# Altium schematic sheet PowerSection.SchDoc
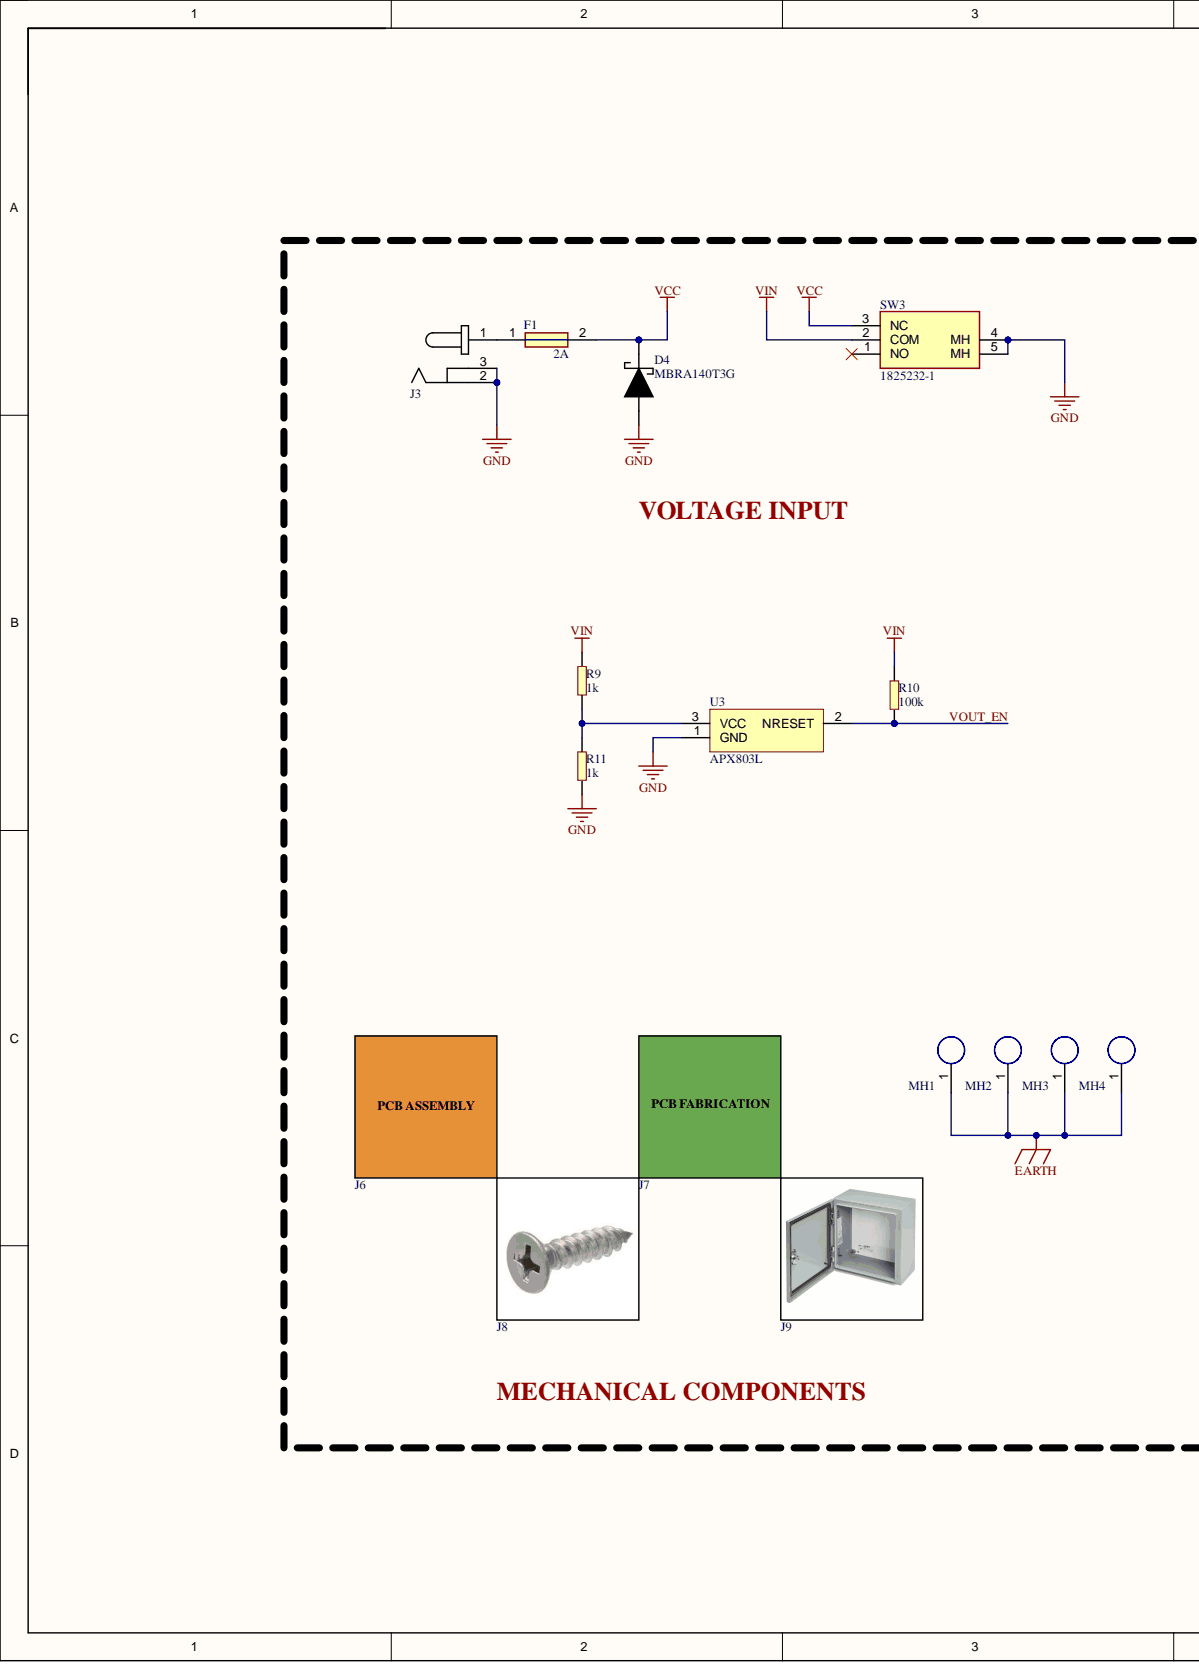
[diagram p0: PowerSection.SchDoc, left]
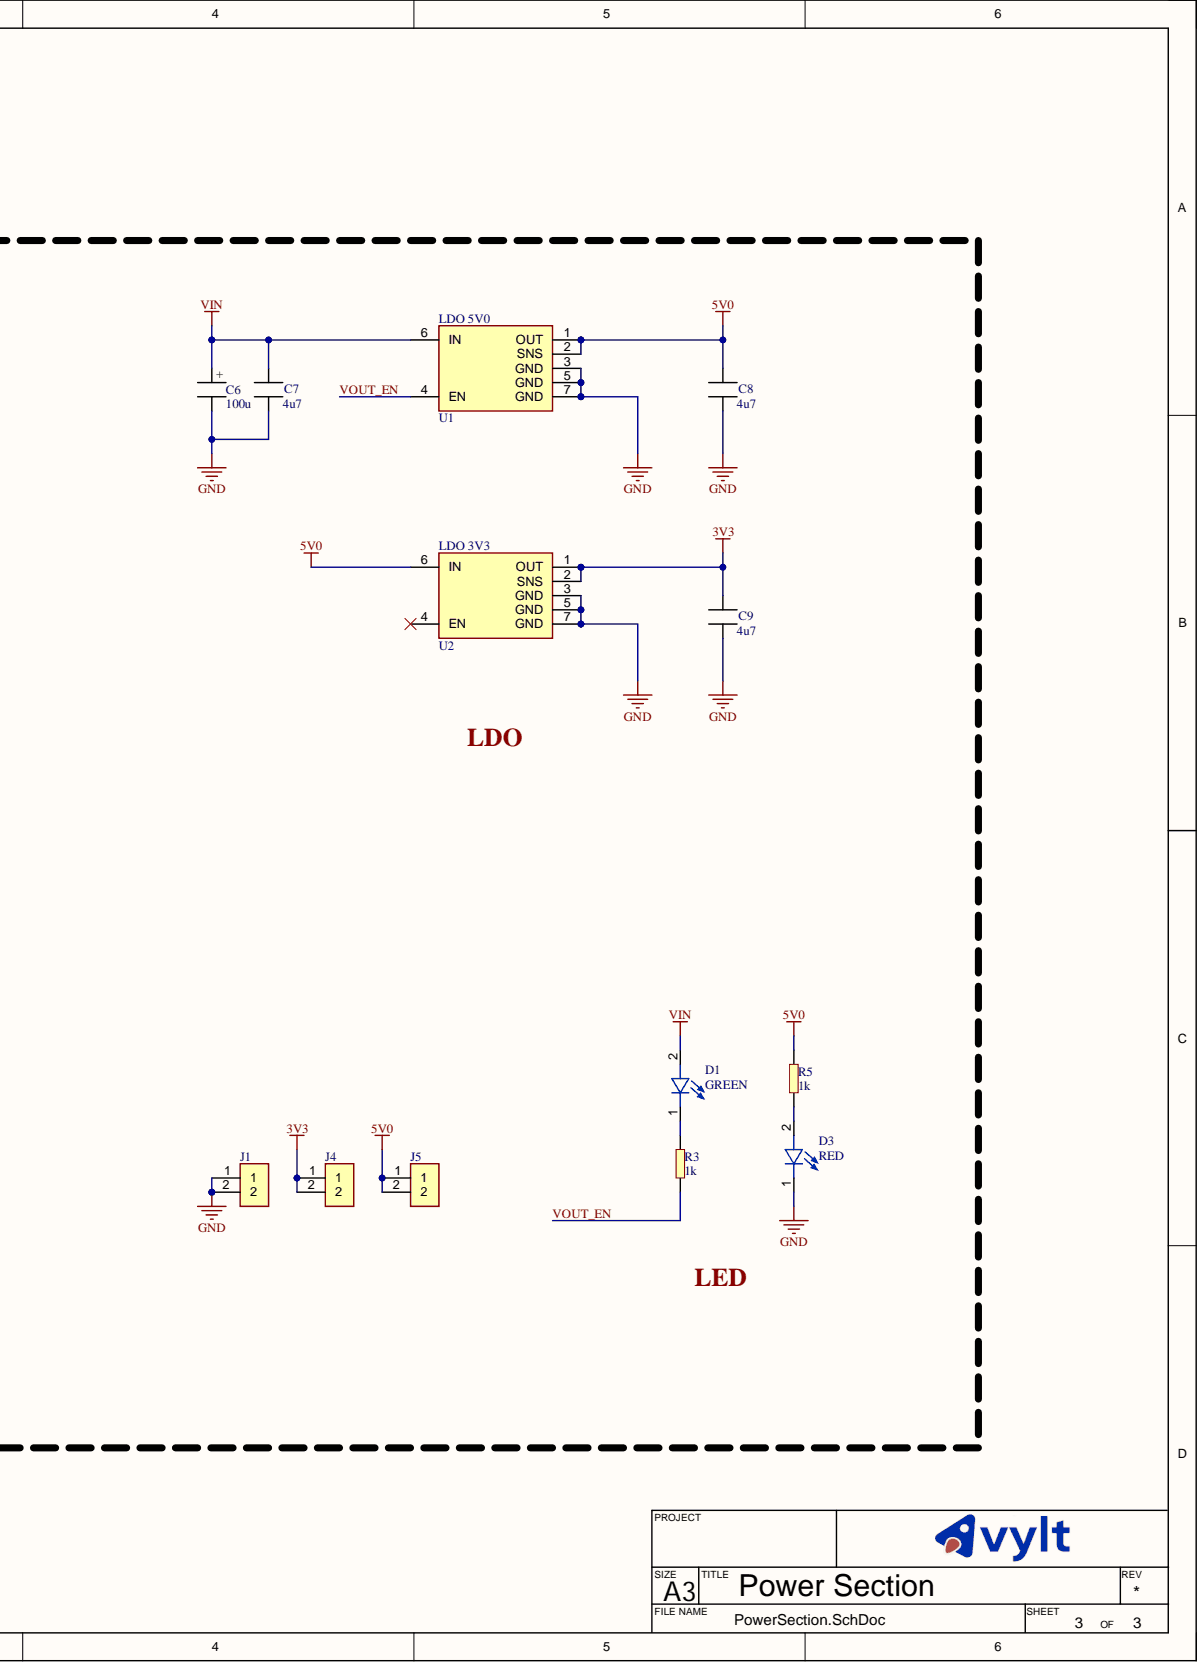
[diagram p1: PowerSection.SchDoc, right]

## Parts
C6  value 100u  [CAP_6.3_7.7]  (hidden comment: ERP_102-2)
C7  value 4u7  [CAPC1608X10N]  (hidden comment: ERP_102-1)
C8  value 4u7  [CAPC1608X10N]  (hidden comment: ERP_102-1)
C9  value 4u7  [CAPC1608X10N]  (hidden comment: ERP_102-1)
D1  value GREEN  [LED_2SMD]  (hidden comment: LED_2SMD)
D3  value RED  [LED_2SMD]  (hidden comment: LED_2SMD)
D4  value MBRA140T3G  Manufacturer Part Number 1: MBRA140T3G  [SS14_SMA]  (hidden comment: ERP_104-3)
F1  value 2A  [0679L]  (hidden comment: 0679L)
J1  ERP_148-2  [2PX1R]  (value not drawn)
J3  KLDX-0202-AC  [KLDX-0202-AC]  (value not drawn)
J4  ERP_148-2  [2PX1R]  (value not drawn)
J5  ERP_148-2  [2PX1R]  (value not drawn)
R3  value 1k  [RES_0603]  (hidden comment: ERP_101-1)
R5  value 1k  [RES_0603]  (hidden comment: ERP_101-1)
R9  value 1k  [RES_0603]  (hidden comment: ERP_101-1)
R10  value 100k  [RES_0603]  (hidden comment: ERP_101-1)
R11  value 1k  [RES_0603]  (hidden comment: ERP_101-1)
SW3  value 1825232-1  Manufacturer Part Number 1: 1825232-1  [3PIN_SPDT_TH_RA]  (hidden comment: ERP_110-4)
U1  value LDO 5V0  [TLV767XXDRV]  (hidden comment: TLV767XXDRV)
U2  value LDO 3V3  [TLV767XXDRV]  (hidden comment: TLV767XXDRV)
U3  APX803L  [APX803L]

## Nets
3V3: C9.1 J4.1 J4.2 U2.1(OUT) U2.2(SNS)
5V0: C8.1 J5.1 J5.2 R5.1 U1.1(OUT) U1.2(SNS) U2.6(IN)
GND: C6.2 C7.2 C8.2 C9.2 D3.1 D4.2 J1.1 J1.2 J3.2 J3.3 R11.1 SW3.4(MH) SW3.5(MH) U1.3(GND) U1.5(GND) U1.7(GND) U2.3(GND) U2.5(GND) U2.7(GND) U3.1
VCC: D4.1 F1.2 SW3.3(NC)
VIN: C6.1 C7.1 D1.2 R9.2 R10.2 SW3.2(COM) U1.6(IN)
VOUT_EN: R3.1 R10.1 U1.4(EN) U3.2
NetD1_1: D1.1 R3.2
NetD3_2: D3.2 R5.2
NetF1_1: F1.1 J3.1
NetR9_1: R9.1 R11.2 U3.3
EARTH:

Not connected: SW3 1(NO); U2 4(EN)

## Library data of the parts
C6 = CAP_6.3_7.7: Electrolytic Capacitor SMD 6.3x7.7; Manufacturer 1: Nichicon; Manufacturer Part Number 1: UWT1V101MCL1GS; Suuplier Part Number 1: 493-2203-1-ND; Component Kind: Standard; Library Name: ElectrolyticCapacitor.SchLib; Package: 6.3x7.7; Supplier 1: Digikey; Supplier Part Number 1: 493-2203-1-ND
C7-C9 = MLCC_0603: MLCC 0603; Manufacturer 1: Murata; Manufacturer Part Number 1: GRM188R6YA475KE15D; Component Kind: Standard; Library Name: Capacitor.SchLib; Package: 0603; Supplier 1: Digikey; Supplier Part Number 1: 490-7205-1-ND
D1 = LED_2SMD: LED GREEN CLEAR 1204 SMD R/A; Manufacturer 1: Würth Elektronik; Manufacturer Part Number 1: 155124VS73200; Component Kind: Standard; Library Name: LED.SchLib; Package: 1204; Supplier 1: Digikey
D3 = LED_2SMD: LED RED CLEAR 1204 SMD R/A; Manufacturer 1: Würth Elektronik; Manufacturer Part Number 1: 155124RS73200; Component Kind: Standard; Library Name: LED.SchLib; Package: 1204; Supplier 1: Digikey
D4 = SS14_SMA: Manufacturer 1: ONSEMi; Component Kind: Standard; Description: SS14_SMA; Library Name: Schottky.SchLib; Package: SMA; Supplier 1: Digikey
F1 = 0679L: FUSE BRD MNT 2SMD; Manufacturer 1: Bel Fuse Inc.; Manufacturer Part Number 1: 0679L2000-05; Component Kind: Standard; Library Name: BlowFuse.SchLib
J1 J4 J5 = 2PX1R: Component Kind: Standard; Description: 2PX1R; Library Name: Male_Header_P2.54.SchLib
J3 = KLDX-0202-AC: CONN PWR JACK 2X5.5MM KINKED PIN; Component Kind: Standard; Library Name: Connectors.SchLib
R3 = RES_0603: Manufacturer 1: YAGEO; Manufacturer Part Number 1: RC0603JR-07330RL; Component Kind: Standard; Description: RES_0603; Library Name: Resistor.SchLib; Package: 0603
R5 = RES_0603: Manufacturer 1: YAGEO; Manufacturer Part Number 1: RC0603FR-071KL; Component Kind: Standard; Description: RES_0603; Library Name: Resistor.SchLib; Package: 0603; Supplier 1: Digikey; Supplier Part Number 1: 311-1.00KHRCT-ND
R9-R11 = RES_0603: Component Kind: Standard; Description: RES_0603; Library Name: Resistor.SchLib; Package: 0603
SW3 = 3PIN_SPDT_TH_RA: Manufacturer 1: TE; Component Kind: Standard; Library Name: Switch.SchLib; Package: 6X6; Supplier 2: Digikey; Supplier Part Number 2: A107673-ND
U1 = TLV767XXDRV: IC REG LINEAR; Manufacturer 1: Texas Instruments; Manufacturer Part Number 1: TLV76750DRVR; Component Kind: Standard; Library Name: VoltageRegulator.SchLib
U2 = TLV767XXDRV: IC REG LINEAR; Manufacturer 1: Texas Instruments; Manufacturer Part Number 1: TLV76733DRVR; Component Kind: Standard; Library Name: VoltageRegulator.SchLib
U3 = APX803L: IC SUPERVISOR 1 CHANNEL SOT23; Manufacturer 1: Diodes Incorporated; Manufacturer Part Number 1: APX803L20-35SA-7; Component Kind: Standard; Library Name: VoltageDetector.SchLib; Value: APX803L
